# Altium schematic sheet Drive.SchDoc(Drive)
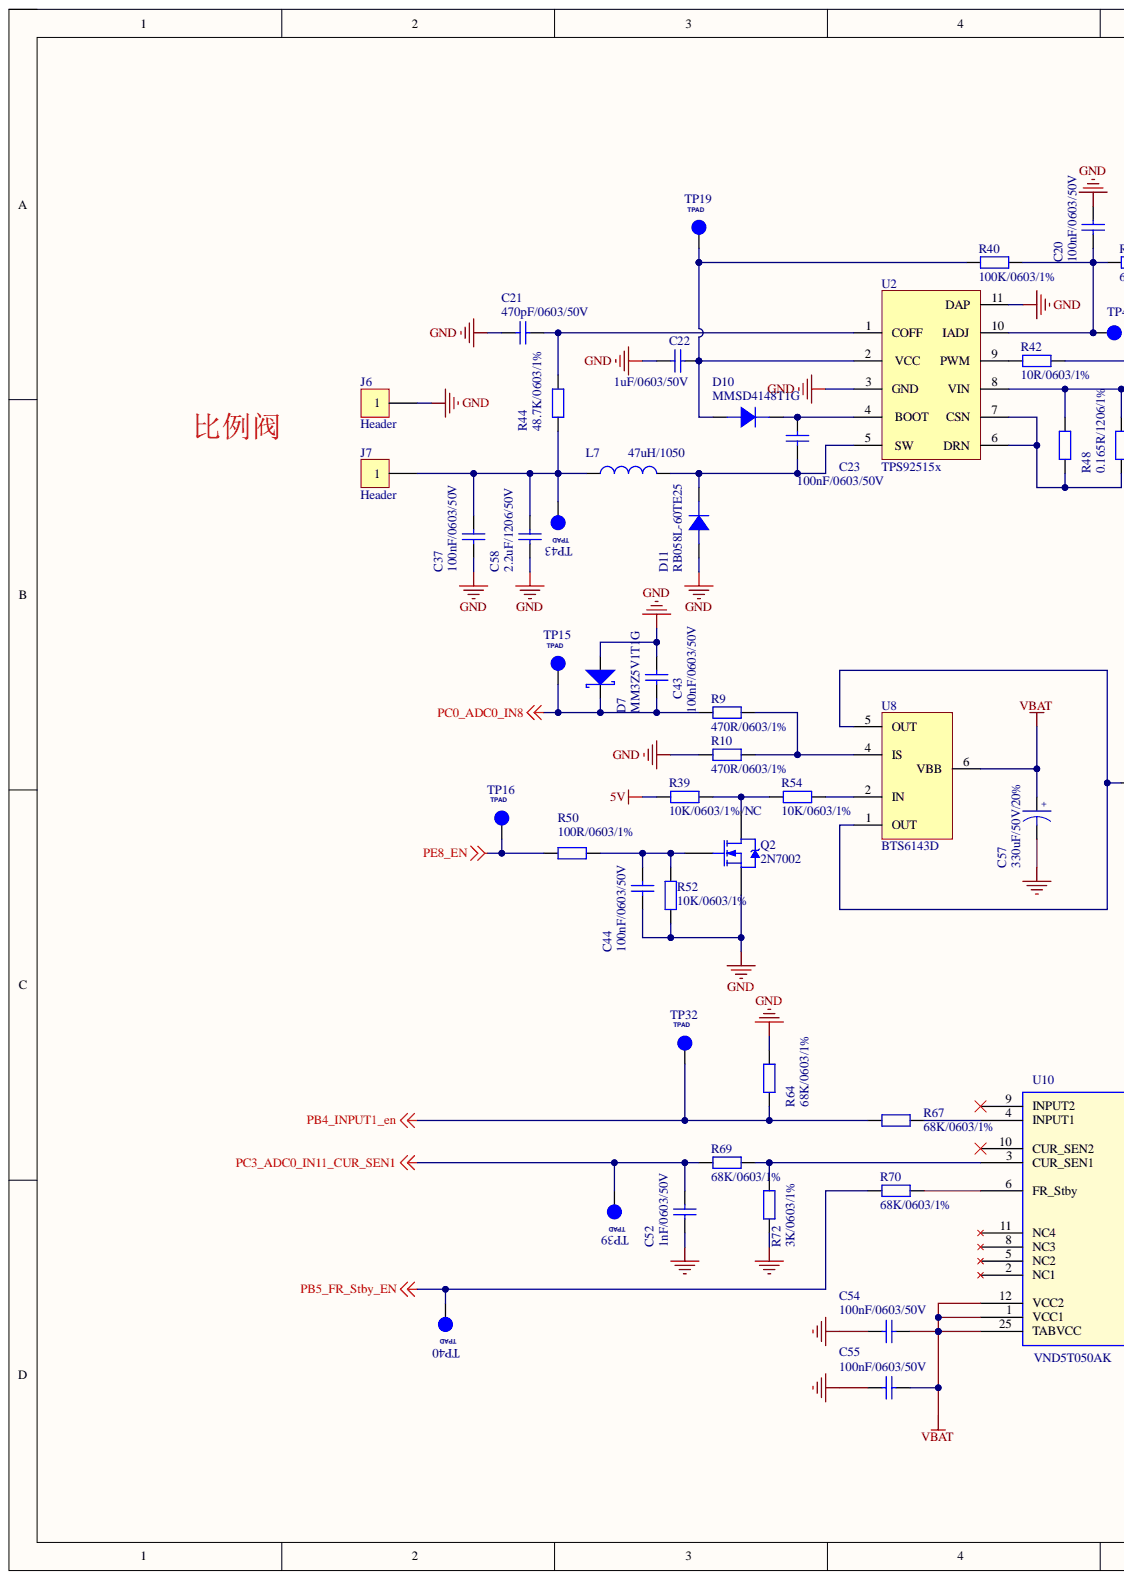
[diagram p0: Drive.SchDoc, left]
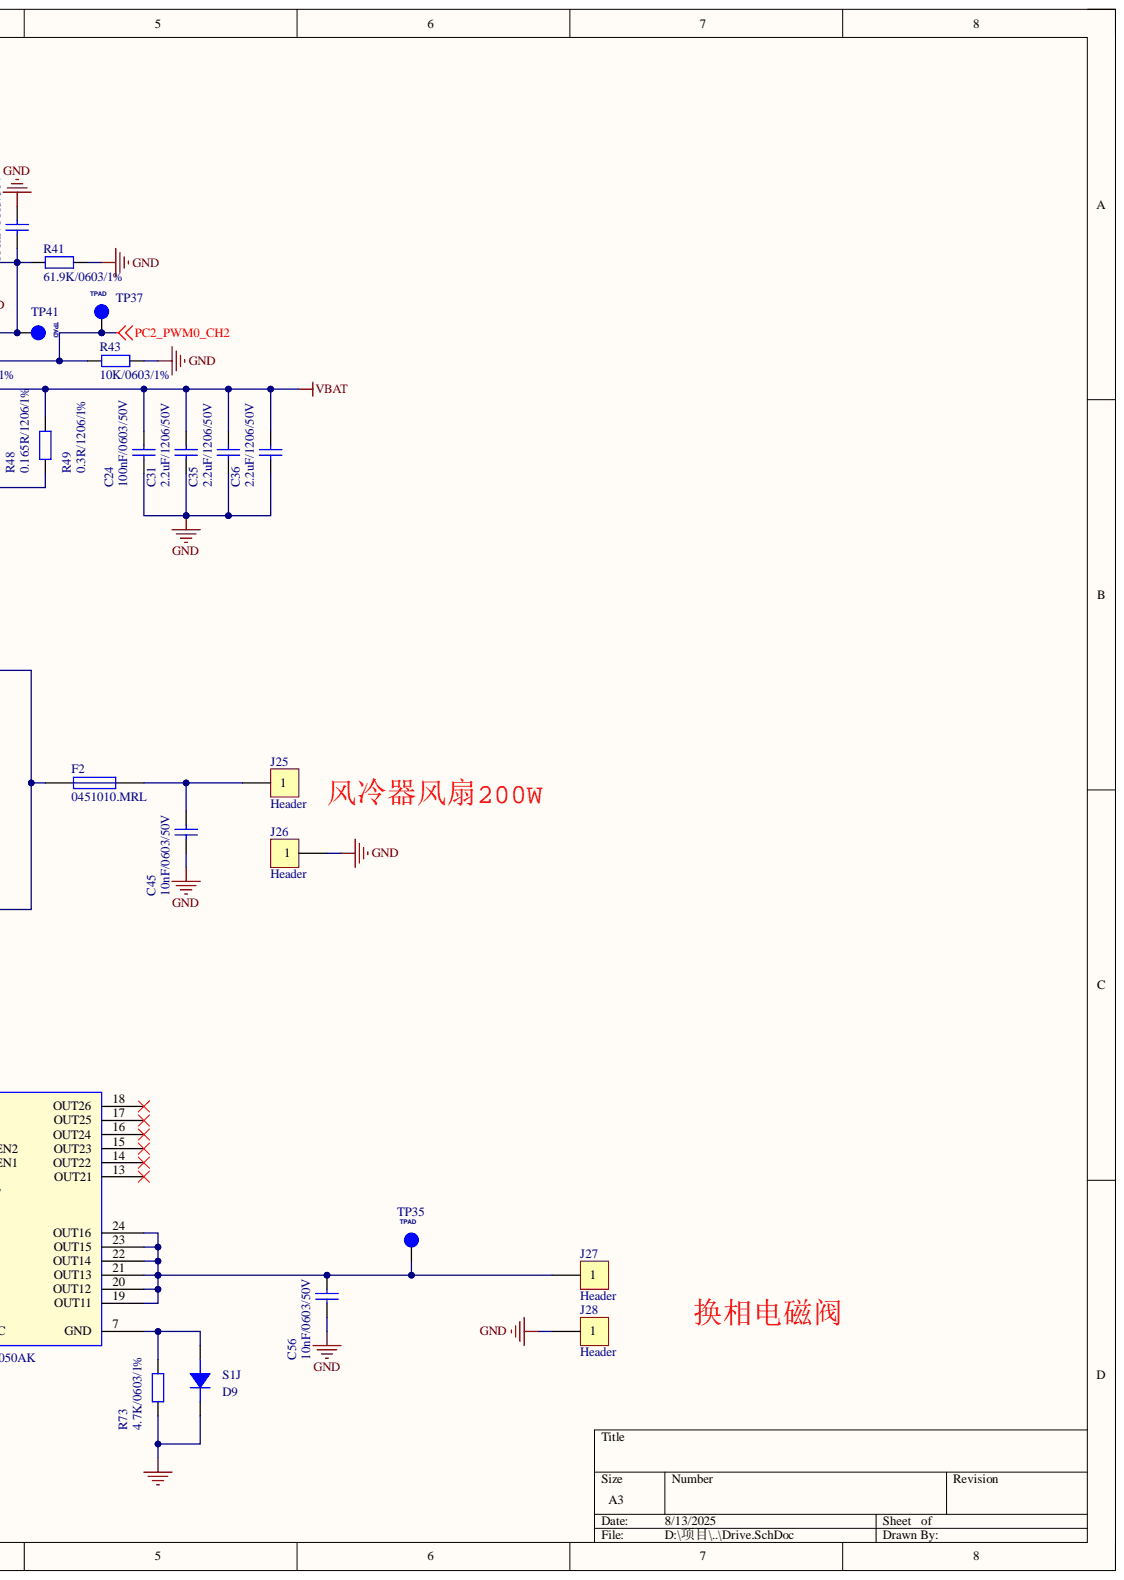
[diagram p1: Drive.SchDoc, right]

## Parts
C20  100nF/0603/50V  [RC0603]
C21  470pF/0603/50V  [RC0603]
C22  1uF/0603/50V  [RC0603]
C23  100nF/0603/50V  [RC0603]
C24  100nF/0603/50V  [RC0603]
C31  2.2uF/1206/50V  [R1206]
C35  2.2uF/1206/50V  [R1206]
C36  2.2uF/1206/50V  [R1206]
C37  100nF/0603/50V  [RC0603]
C43  100nF/0603/50V  [RC0603]
C44  100nF/0603/50V  [RC0603]
C45  10nF/0603/50V  [RC0603]
C52  1nF/0603/50V  [RC0603]
C54  100nF/0603/50V  [RC0603]
C55  100nF/0603/50V  [RC0603]
C56  10nF/0603/50V  [RC0603]
C57  330uF/50V/20%  [CAP-TH_BD12.5-P5.00-D0.6-FD]
C58  2.2uF/1206/50V  [R1206]
D7  MM3Z5V1T1G  [SOD-323]
D9  S1J  [SMA(DO-214AC)]
D10  MMSD4148T1G  [SOD-123]
D11  RB058L-60TE25  [SMA(DO-214AC)]
F2  0451010.MRL  [FUSE]
J6  Header  [J3]
J7  Header  [J3]
J25  Header  [J3]
J26  Header  [J3]
J27  Header  [J3]
J28  Header  [J3]
L7  47uH/1050  [SMD_L12.3-W12.3]
Q2  2N7002  [SOT-23]
R9  470R/0603/1%  [RC0603]
R10  470R/0603/1%  [RC0603]
R39  10K/0603/1%/NC  [RC0603]
R40  100K/0603/1%  [RC0603]
R41  61.9K/0603/1%  [RC0603]
R42  10R/0603/1%  [RC0603]
R43  10K/0603/1%  [RC0603]
R44  48.7K/0603/1%  [RC0603]
R48  0.165R/1206/1%  [R1206]
R49  0.3R/1206/1%  [R1206]
R50  100R/0603/1%  [RC0603]
R52  10K/0603/1%  [RC0603]
R54  10K/0603/1%  [RC0603]
R64  68K/0603/1%  [RC0603]
R67  68K/0603/1%  [RC0603]
R69  68K/0603/1%  [RC0603]
R70  68K/0603/1%  [RC0603]
R72  3K/0603/1%  [RC0603]
R73  4.7K/0603/1%  [RC0603]
TP15  NOTE: TPAD  [TP]  (hidden comment: TPAD_030)
TP16  NOTE: TPAD  [TP]  (hidden comment: TPAD_030)
TP19  NOTE: TPAD  [TP]  (hidden comment: TPAD_030)
TP32  NOTE: TPAD  [TP]  (hidden comment: TPAD_030)
TP35  NOTE: TPAD  [TP]  (hidden comment: TPAD_030)
TP37  NOTE: TPAD  [TP]  (hidden comment: TPAD_030)
TP39  NOTE: TPAD  [TP]  (hidden comment: TPAD_030)
TP40  NOTE: TPAD  [TP]  (hidden comment: TPAD_030)
TP41  NOTE: TPAD  [TP]  (hidden comment: TPAD_030)
TP43  NOTE: TPAD  [TP]  (hidden comment: TPAD_030)
U2  TPS92515x  [TPS92515]
U8  BTS6143D  [TO-252-5]
U10  VND5T050AK  [POWERSSO-24-EP]

## Nets
5V: R39.1
GND: C20.2 C21.1 C22.2 C24.1 C31.1 C35.1 C36.1 C37.1 C43.1 C44.1 C45.1 C52.1 C54.2 C55.2 C56.1 C57.2 C58.1 D7.1 D9.2 D11.1 J6.1 J26.1 J28.1 Q2.2 R10.1 R41.1 R43.2 R52.2 R64.2 R72.1 R73.1 U2.3(GND) U2.11(DAP)
PB4_INPUT1_en: R64.1 R67.2 TP32.1
PB5_FR_Stby_EN: R70.2 TP40.1
PC0_ADC0_IN8: C43.2 D7.2 R9.1 TP15.1
PC2_PWM0_CH2: R42.1 R43.1 TP37.1
PC3_ADC0_IN11_CUR_SEN1: C52.2 R69.2 TP39.1
PE8_EN: R50.1 TP16.1
VBAT: C24.2 C31.2 C35.2 C36.2 C54.1 C55.1 C57.1 R48.2 R49.2 U2.8(VIN) U8.6(VBB) U10.1(VCC1) U10.12(VCC2) U10.25(TABVCC)
NetC20_1: C20.1 R40.1 R41.2 TP41.1 U2.10(IADJ)
NetC21_2: C21.2 R44.2 U2.1(COFF)
NetC22_1: C22.1 D10.1 R40.2 TP19.1 U2.2(VCC)
NetC23_1: C23.1 D11.2 L7.2 U2.5(SW)
NetC23_2: C23.2 D10.2 U2.4(BOOT)
NetC37_2: C37.2 C58.2 J7.1 L7.1 R44.1 TP43.1
NetC44_2: C44.2 Q2.1 R50.2 R52.1
NetC45_2: C45.2 F2.2 J25.1
NetC56_2: C56.2 J27.1 TP35.1 U10.19(OUT11) U10.20(OUT12) U10.21(OUT13) U10.22(OUT14) U10.23(OUT15) U10.24(OUT16)
NetD9_1: D9.1 R73.2 U10.7(GND)
NetF2_1: F2.1 U8.1(OUT) U8.5(OUT)
NetQ2_3: Q2.3 R39.2 R54.1
NetR9_2: R9.2 R10.2 U8.4(IS)
NetR42_2: R42.2 U2.9(PWM)
NetR48_1: R48.1 R49.1 U2.6(DRN) U2.7(CSN)
NetR54_2: R54.2 U8.2(IN)
NetR67_1: R67.1 U10.4(INPUT1)
NetR69_1: R69.1 R72.2 U10.3(CUR_SEN1)
NetR70_1: R70.1 U10.6(FR_Stby)

Not connected: U10 2(NC1) 5(NC2) 8(NC3) 9(INPUT2) 10(CUR_SEN2) 11(NC4) 13(OUT21) 14(OUT22) 15(OUT23) 16(OUT24) 17(OUT25) 18(OUT26)

## Library data of the parts
C20-C24 C31 C35-C37 C43-C45 C52 C54-C56 C58 = C: 贴片电容/MLCC电容; BOM名称: 无极性贴片电容; 联系下单店铺卖家 免费帮你查找封装: 联系下单店铺卖家 免费技术指导; Component Kind: Standard; Library Name: 0.1 - 电阻-电容-电感-保险丝-晶振-晶体-磁珠-电位器-法拉电容-馈通电容.SchLib
C57 = EC_S_470U35V20%-10x10.5: 电解电容/贴片/10*10.5/470uF/35V/20%/(-40℃～+105℃)/先科/无铅; Part NO.: 0102_4771050; PCB Footprint: C-VZH-1010; Spec.: VEJ471M1VTR-1313; Type: 电解电容; VendorID: Rongju; Component Kind: Standard
D7 = D Schottky: Schottky Diode; Code_JEDEC: DO-214-AA; Component Kind: Standard; LatestRevisionDate: 17-Jul-2002; LatestRevisionNote: Re-released for DXP Platform.; Library Name: Miscellaneous Devices.IntLib; PackageDescription: DO-214-AA/SMB; 2 C-Bend Leads; Body 5.3 x 3.6 mm, inc. leads (LxW); PackageReference: SMB; Published: 8-Jun-2000; Signal Integrity: D Schottky; Simulation: SKYDIODE; Publisher: Altium Limited
D9-D11 = DIODE_2: PCB Footprint: sma; Component Kind: Standard
F2 = Fuse 1: Fuse; Component Kind: Standard; LatestRevisionDate: 17-Jul-2002; LatestRevisionNote: Re-released for DXP Platform.; Library Name: Miscellaneous Devices.IntLib; PackageDescription: Thru-Hole; 2 Leads; PackageReference: PIN-W2/E2.8; Published: 8-Jun-2000; Simulation: FUSE; Publisher: Altium Limited
L7 = Inductor: Code_IEC: 1005; Value: 10mH; Code_JEITA: 0402; Component Kind: Standard; Description: Inductor; LatestRevisionDate: 29-May-2009; LatestRevisionNote: IPC-7351 Footprint Added.; Library Name: Miscellaneous Devices.IntLib; PackageDescription: Chip Inductor; PackageReference: 0402-A; Published: 8-Jun-2000; Signal Integrity: Inductor; Simulation: INDUCTOR; Publisher: Altium Limited
Q2 = NMOS-2: N-Channel Power MOSFET; Component Kind: Standard; LatestRevisionNote: Re-released for DXP Platform.; Library Name: Miscellaneous Devices.IntLib; PackageReference: TO-220AB; Simulation: IRF1010
R9 R10 R39-R44 R48-R50 R52 R54 R64 R67 R69 R70 R72 R73 = R: 贴片电阻; 联系下单店铺卖家 免费帮你查找封装: 联系下单店铺卖家 免费技术指导; BOM名称: 贴片电阻; Component Kind: Standard; Library Name: 0.1 - 电阻-电容-电感-保险丝-晶振-晶体-磁珠-电位器-法拉电容-馈通电容.SchLib
TP15 TP16 TP19 TP32 TP35 TP37 TP39-TP41 TP43 = TESTLOOP: TEST POINT PAD 30MIL DIA SMT, NO PART TO ORDER; AGILE_PN: 211-76642; CAD_APPROVED: Yes; CLASS: IO; MFG_NAME01: NOTACOMPONENT; PCB Footprint: test_smd_1_2mm; ROOM: POWER SUPPLY_LR; 3D_Mechanical_Footprint_File: http://fcl.am.freescale.net/ALLEGRO/step/tpad_030.STEP; Component Kind: Standard; HEIGHT: 0.1MM; MFG_PN01: NOTACOMPONENT; ROHS: Yes
U10 = VND5T035LAK: PCB Footprint: powerssop-24; Component Kind: Standard
J6 J7 J25-J28 = Header: Component Kind: Standard; Library Name: ZZL_ZY_AC7840.SchLib
U2 = TPS92515x: Component Kind: Standard; Library Name: High_Pressure.SchLib
U8 = BTS6143D: Component Kind: Standard; Library Name: High_Pressure_2507.SchLib
